# Altium schematic sheet BC95.SchDoc(BC95)
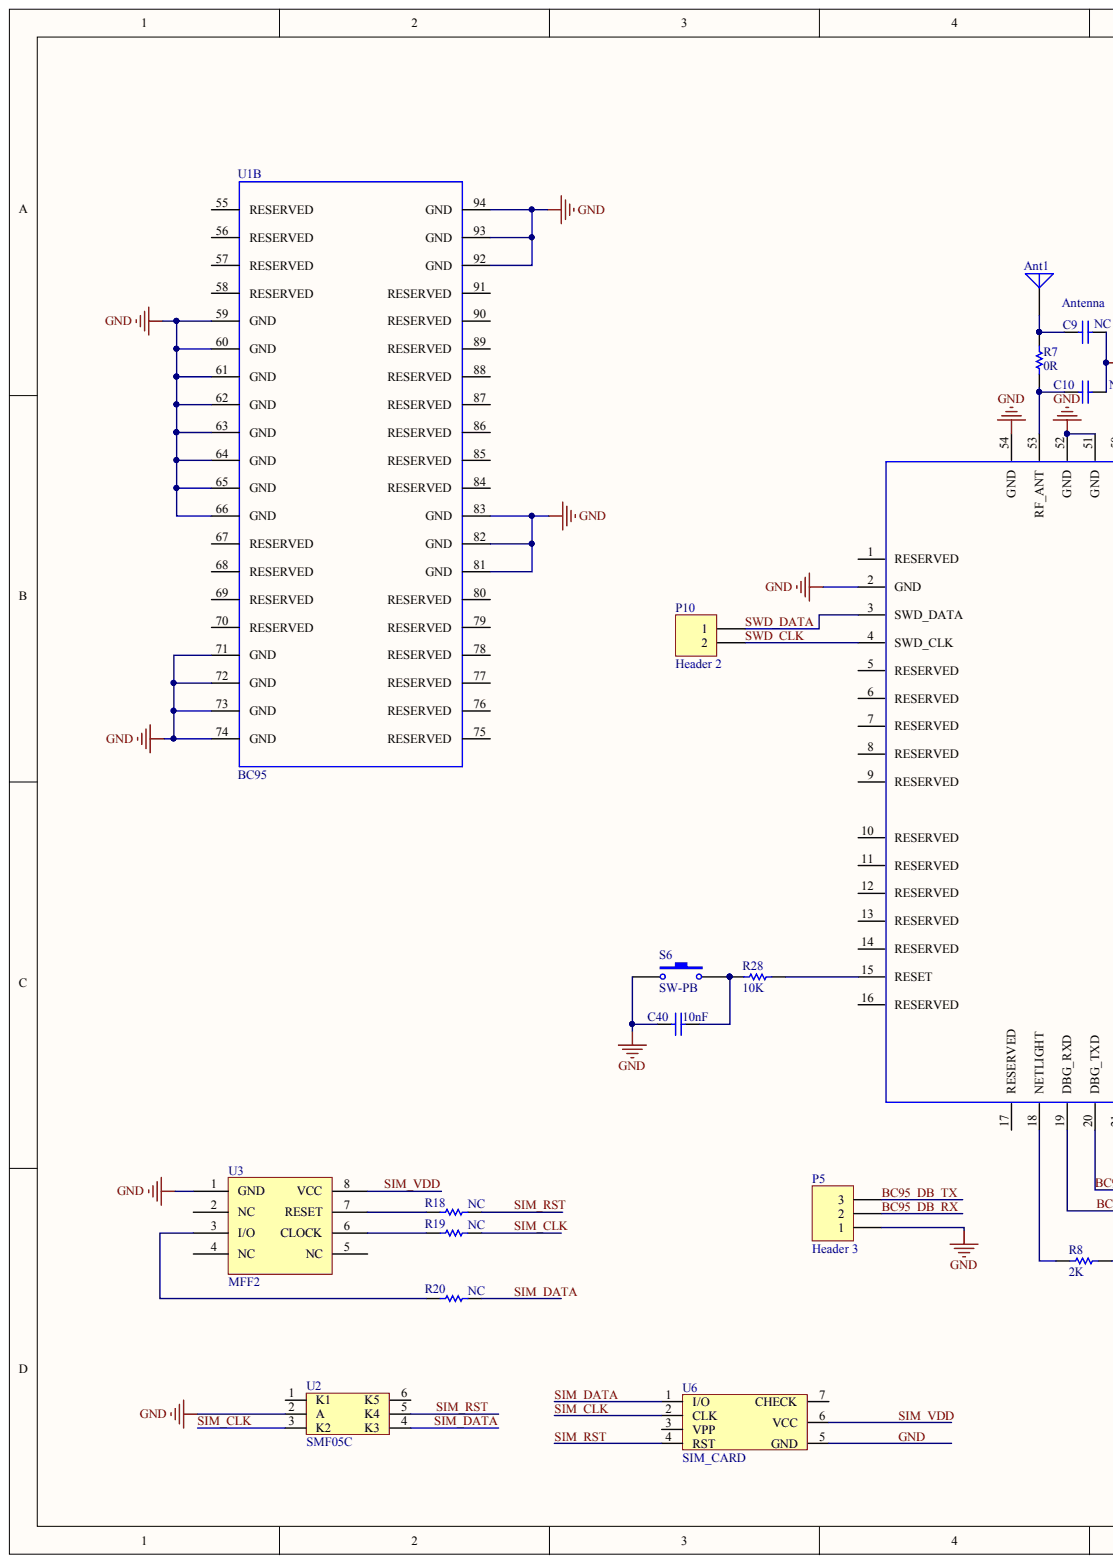
[diagram p0: BC95.SchDoc, left]
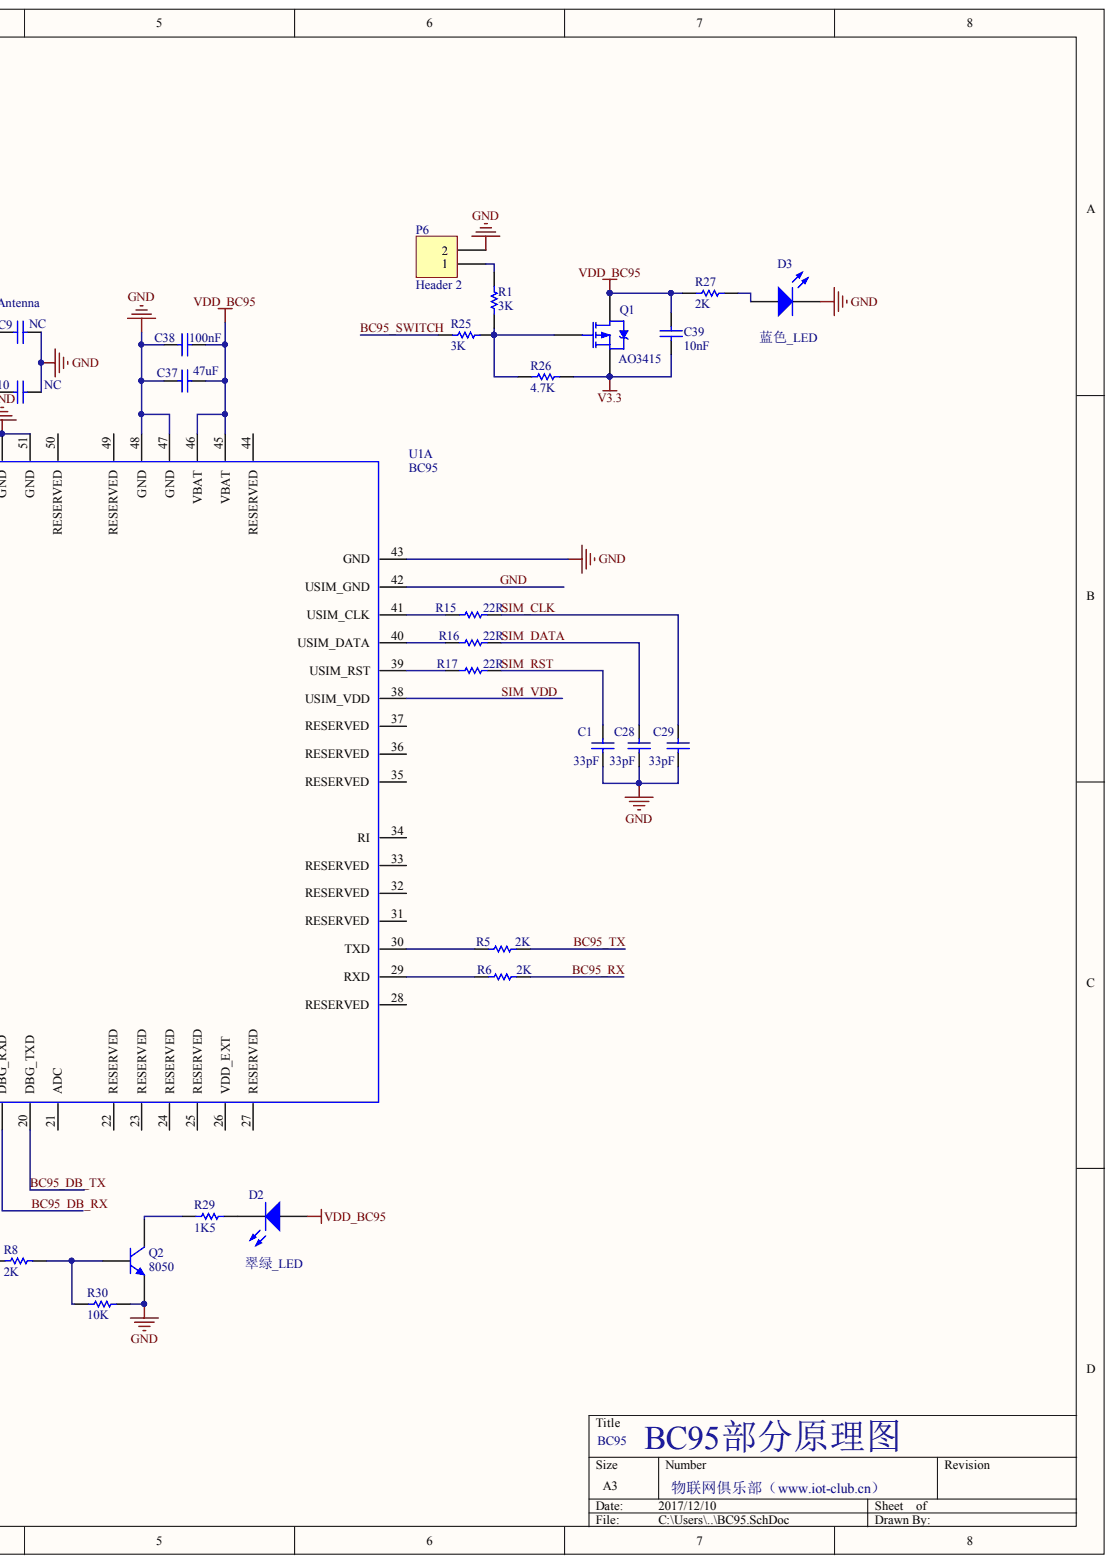
[diagram p1: BC95.SchDoc, right]

## Parts
Ant1  Antenna  [ANTENNA_2]  (no BOM)
C1  33pF  [C0603]
C9  NC  [C0603]  (no BOM)
C10  NC  [C0603]  (no BOM)
C28  33pF  [C0603]
C29  33pF  [C0603]
C37  47uF  [LC-1206_C]
C38  100nF  [C0603]
C39  10nF  [C0603]
C40  10nF  [C0603]
D2  翠绿_LED  [LED_0805]
D3  蓝色_LED  [LED_0805]
P5  Header 3  [HDR1X3]  (no BOM)
P6  Header 2  [HDR1X2]  (no BOM)
P10  Header 2  [HDR1X2]  (no BOM)
Q1  AO3415  [SOT23_N]
Q2  8050  [SOT23_N - 123]
R1  3K  [R0603]
R5  2K  [R0603]
R6  2K  [R0603]
R7  0R  [R0603]
R8  2K  [R0603]
R15  22R  [R0603]
R16  22R  [R0603]
R17  22R  [R0603]
R18  NC  [R0603]  (no BOM)
R19  NC  [R0603]  (no BOM)
R20  NC  [R0603]  (no BOM)
R25  3K  [R0603]
R26  4.7K  [R0603]
R27  2K  [R0603]
R28  10K  [R0603]
R29  1K5  [R0603]
R30  10K  [R0603]
S6  SW-PB  [SMD3*6*2.5]  (no BOM)
U1  BC95  [BC95]  (no BOM)  (parts A,B)
U2  SMF05C  [SOT-363]
U3  MFF2  [SIMMFF2]  (no BOM)
U6  SIM_CARD  [SIM1]  (no BOM)

## Nets
V3.3: C39.1 Q1.3 R26.1
VDD_BC95: C37.2 C38.2 C39.2 D2.1 Q1.2 R27.1 U1.45(VBAT) U1.46(VBAT)
BC95_DB_RX: P5.2 U1.19(DBG_RXD)
BC95_DB_TX: P5.3 U1.20(DBG_TXD)
BC95_RX: R6.2
BC95_SWITCH: R25.1
BC95_TX: R5.2
GND: C1.1 C9.2 C10.2 C28.1 C29.1 C37.1 C38.1 C40.1 D3.2 P5.1 P6.2 Q2.2 R30.2 S6.1 U1.2(GND) U1.42(USIM_GND) U1.43(GND) U1.47(GND) U1.48(GND) U1.51(GND) U1.52(GND) U1.54(GND) U1.59(GND) U1.60(GND) U1.61(GND) U1.62(GND) U1.63(GND) U1.64(GND) U1.65(GND) U1.66(GND) U1.71(GND) U1.72(GND) U1.73(GND) U1.74(GND) U1.81(GND) U1.82(GND) U1.83(GND) U1.92(GND) U1.93(GND) U1.94(GND) U2.2(A) U3.1(GND) U6.5(GND)
SIM_CLK: C29.2 R15.2 R19.2 U2.3(K2) U6.2(CLK)
SIM_DATA: C28.2 R16.2 R20.2 U2.4(K3) U6.1(I/O)
SIM_RST: C1.2 R17.2 R18.2 U2.5(K4) U6.4(RST)
SIM_VDD: U1.38(USIM_VDD) U3.8(VCC) U6.6(VCC)
SWD_CLK: P10.2 U1.4(SWD_CLK)
SWD_DATA: P10.1 U1.3(SWD_DATA)
NetAnt1_1: Ant1.1 C9.1 R7.2
NetC10_1: C10.1 R7.1 U1.53(RF_ANT)
NetC40_2: C40.2 R28.1 S6.2
NetD2_2: D2.2 R29.2
NetD3_1: D3.1 R27.2
NetP6_1: P6.1 R1.2
NetQ1_1: Q1.1 R1.1 R25.2 R26.2
NetQ2_1: Q2.1 R8.2 R30.1
NetQ2_3: Q2.3 R29.1
NetR5_1: R5.1 U1.30(TXD)
NetR6_1: R6.1 U1.29(RXD)
NetR8_1: R8.1 U1.18(NETLIGHT)
NetR15_1: R15.1 U1.41(USIM_CLK)
NetR16_1: R16.1 U1.40(USIM_DATA)
NetR17_1: R17.1 U1.39(USIM_RST)
NetR18_1: R18.1 U3.7(RESET)
NetR19_1: R19.1 U3.6(CLOCK)
NetR20_1: R20.1 U3.3(I/O)
NetR28_2: R28.2 U1.15(RESET)

Not connected: U1 1(RESERVED) 5(RESERVED) 6(RESERVED) 7(RESERVED) 8(RESERVED) 9(RESERVED) 10(RESERVED) 11(RESERVED) 12(RESERVED) 13(RESERVED) 14(RESERVED) 16(RESERVED) 17(RESERVED) 21(ADC) 22(RESERVED) 23(RESERVED) 24(RESERVED) 25(RESERVED) 26(VDD_EXT) 27(RESERVED) 28(RESERVED) 31(RESERVED) 32(RESERVED) 33(RESERVED) 34(RI) 35(RESERVED) 36(RESERVED) 37(RESERVED) 44(RESERVED) 49(RESERVED) 50(RESERVED) 55(RESERVED) 56(RESERVED) 57(RESERVED) 58(RESERVED) 67(RESERVED) 68(RESERVED) 69(RESERVED) 70(RESERVED) 75(RESERVED) 76(RESERVED) 77(RESERVED) 78(RESERVED) 79(RESERVED) 80(RESERVED) 84(RESERVED) 85(RESERVED) 86(RESERVED) 87(RESERVED) 88(RESERVED) 89(RESERVED) 90(RESERVED) 91(RESERVED); U2 1(K1) 6(K5); U3 2(NC) 4(NC) 5(NC); U6 3(VPP) 7(CHECK)

## Library data of the parts
Ant1 = Antenna: Generic Antenna; Published: 24-Mar-1999; PackageReference: PIN1; Library Name: Devices.IntLib; LatestRevisionNote: Re-released for DXP Platform.; LatestRevisionDate: 17-Jul-2002; Publisher: Altium Limited
C1 C9 C10 C28 C29 C37-C40 = CAP: Capacitor; Library Name: Devices.IntLib
  C1 C28 C29 C37-C40: Component Kind: Standard
D2 D3 = LED1: Typical RED GaAs LED; Simulation: LED1; Published: 8-Jun-2000; PackageReference: LED-1; PackageDescription: LED; 2 Leads; Library Name: Devices.IntLib; LatestRevisionNote: Mech Layer 1 removed.; LatestRevisionDate: 08-Jul-2005; Component Kind: Standard; Publisher: Altium Limited
P5 = Header 3: Header, 3-Pin; Signal Integrity: Connector; Library Name: Connectors.IntLib; LatestRevisionNote: Re-released for DXP Platform.; LatestRevisionDate: 17-Jul-2002; Publisher: Altium Limited
P6 P10 = Header 2: Header, 2-Pin; Signal Integrity: Connector; Library Name: Connectors.IntLib; LatestRevisionNote: Re-released for DXP Platform.; LatestRevisionDate: 17-Jul-2002; Publisher: Altium Limited
Q1 = PMOS-2: P-Channel Power MOSFET; Simulation: IRF9510; Library Name: Devices.IntLib; Component Kind: Standard
Q2 = NPN: NPN Bipolar Transistor; Simulation: NPN; Signal Integrity: NPN; Published: 8-Jun-2000; PackageReference: TO-226-AA; PackageDescription: TO, Flat Index; 3 In-Line, Axial Leads; Body Dia. 4.8mm; Leads 0.55 x 0.51 mm (max); Library Name: Devices.IntLib; LatestRevisionNote: Re-released for DXP Platform.; LatestRevisionDate: 17-Jul-2002; Component Kind: Standard; Code_JEDEC: TO-226-AA; Publisher: Altium Limited
R1 R5-R8 R15-R20 R25-R30 = Res1: Resistor; Value: 1K; Simulation: RESISTOR; Signal Integrity: Res; Published: 8-Jun-2000; PackageReference: AXIAL-0.3; PackageDescription: Axial Device, Thru-Hole; 2 Leads; 0.3 in Pin Spacing; Library Name: Devices.IntLib; LatestRevisionNote: Re-released for DXP Platform.; LatestRevisionDate: 17-Jul-2002; Publisher: Altium Limited
  R1 R5-R8 R15-R17 R25-R30: Component Kind: Standard
S6 = SW-PB: Switch; Published: 24-Mar-1999; PackageReference: SPST-2; PackageDescription: Switch; 2 Leads; Library Name: Devices.IntLib; LatestRevisionNote: Re-released for DXP Platform.; LatestRevisionDate: 17-Jul-2002; Publisher: Altium Limited
U2 = SMF05C: TVS; Library Name: Custom.IntLib; Component Kind: Standard
U6 = SIM_CARD: 手机SIM卡; Library Name: Custom.IntLib
U1 = BC95: Library Name: Custom.IntLib
U3 = MFF2: Library Name: IC.IntLib; Description: MFF2
